# Altium schematic sheet Sheet1.SchDoc(Sheet1)
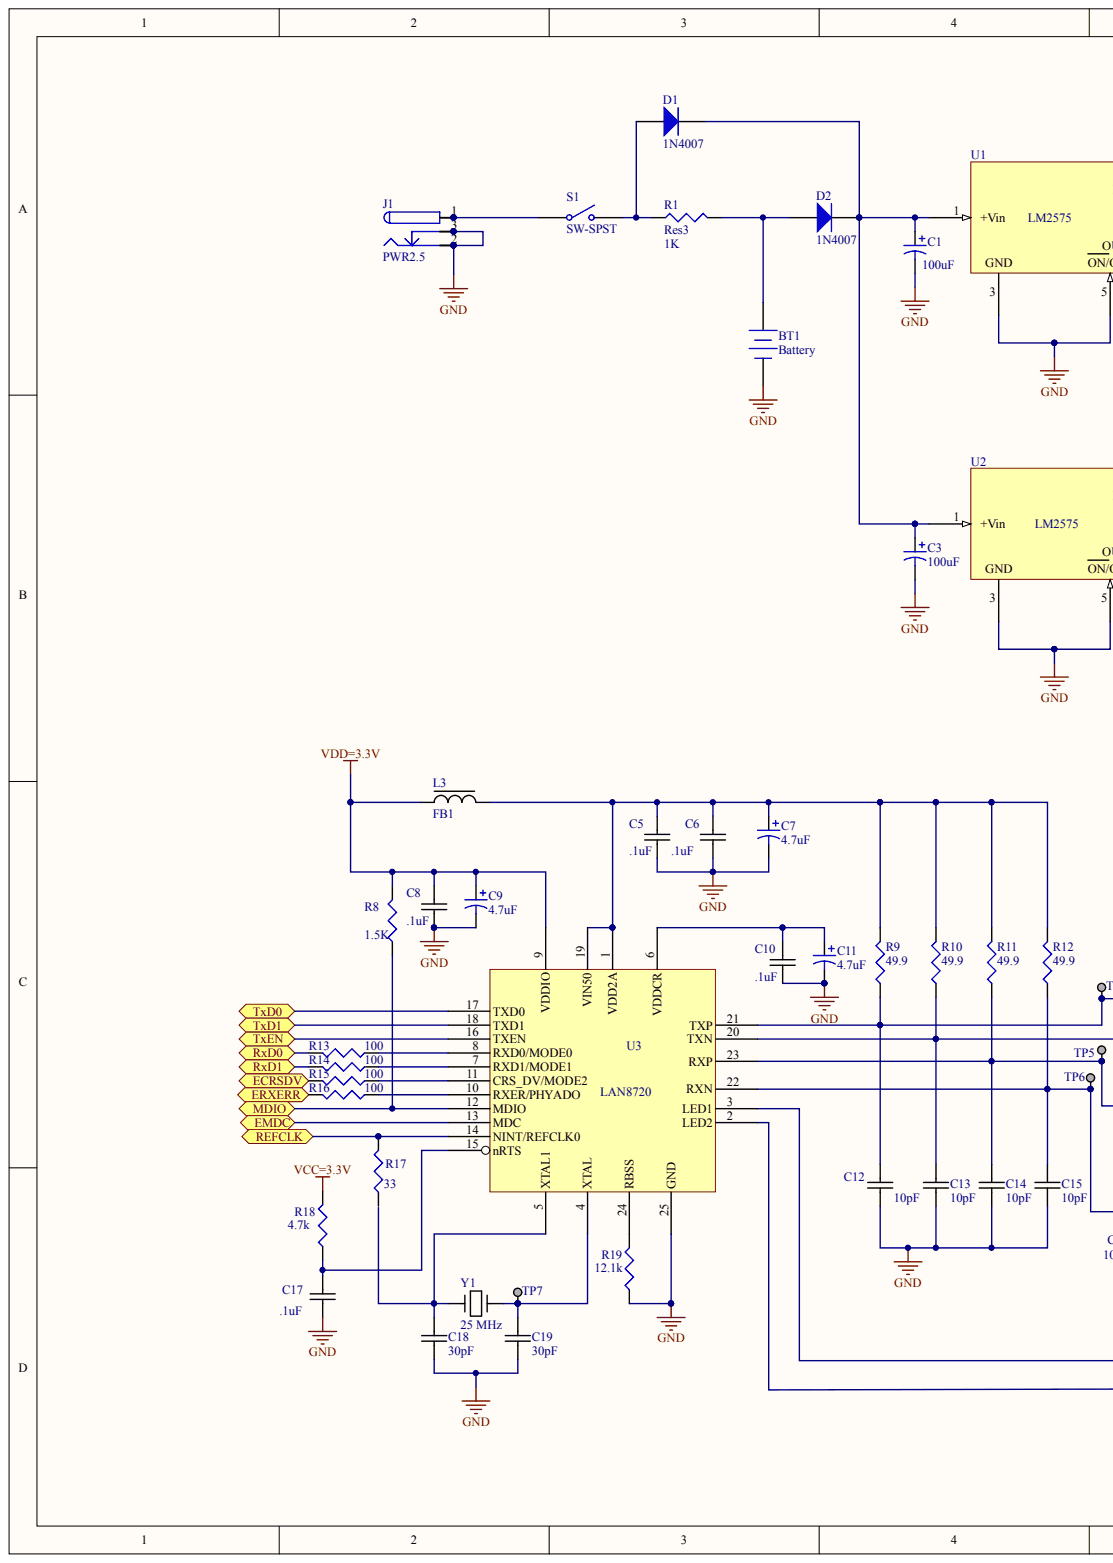
[diagram p0: Sheet1.SchDoc, left]
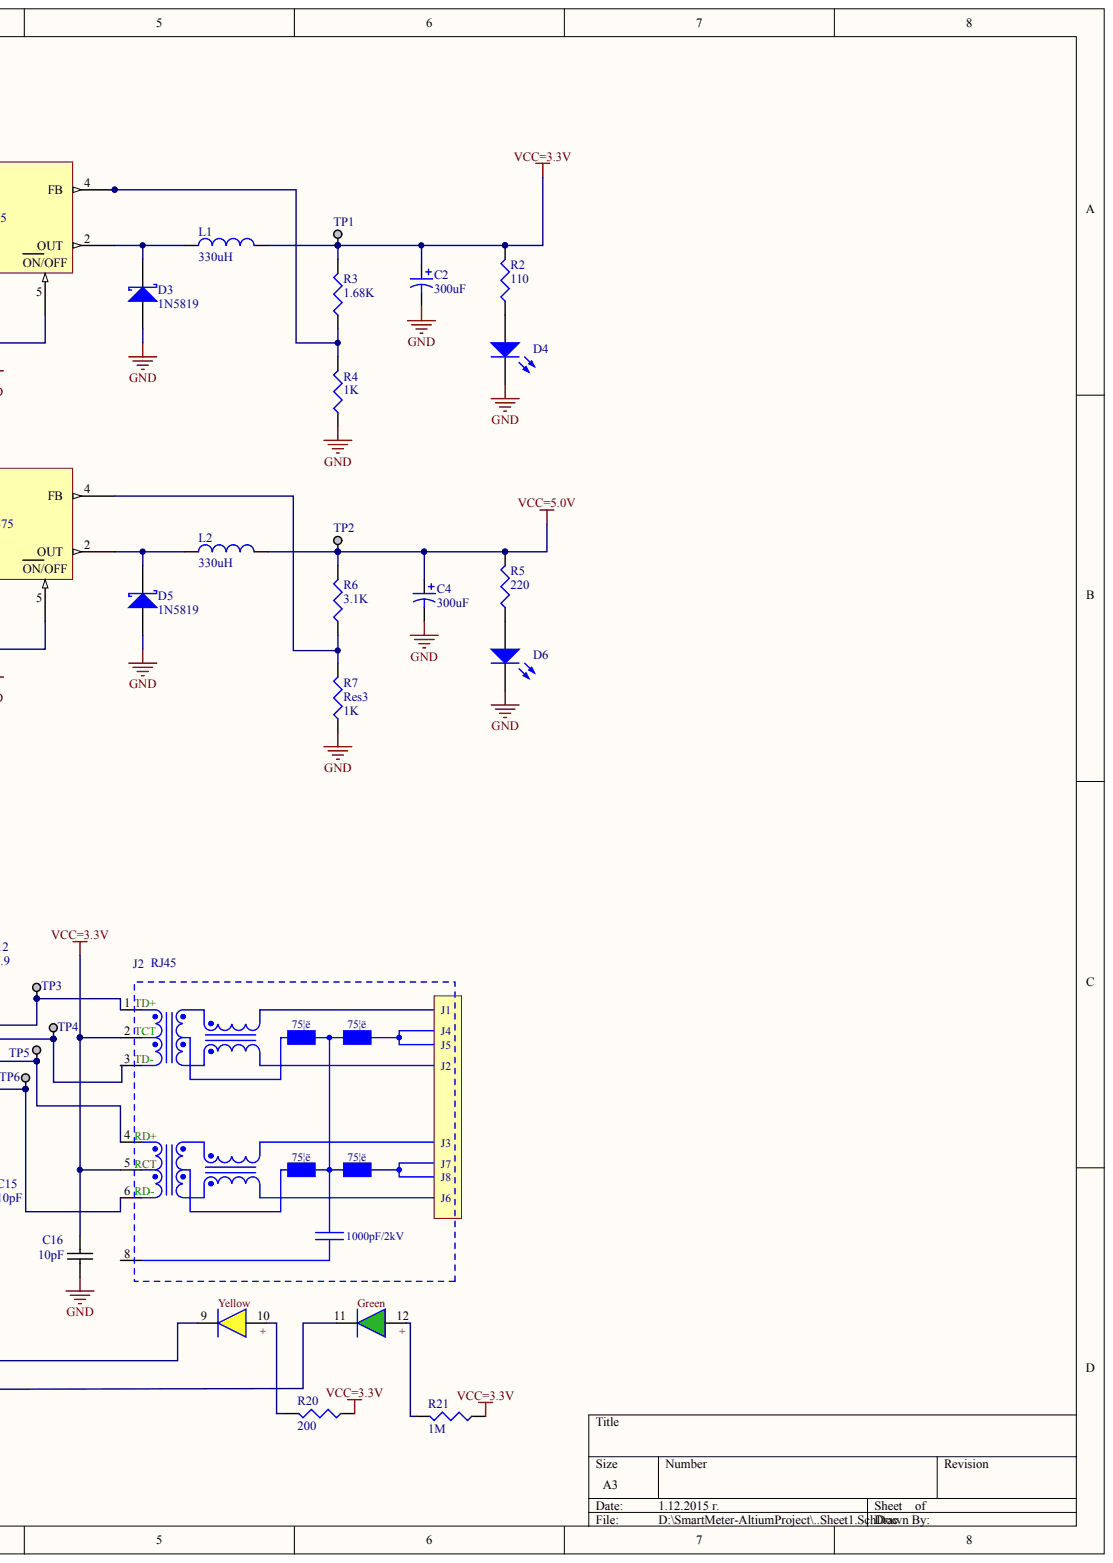
[diagram p1: Sheet1.SchDoc, right]

## Parts
BT1  Battery
C1  100uF
C2  300uF
C3  100uF
C4  300uF
C5  .1uF
C6  .1uF
C7  4.7uF
C8  .1uF
C9  4.7uF
C10  .1uF
C11  4.7uF
C12  10pF
C13  10pF
C14  10pF
C15  10pF
C16  10pF
C17  .1uF
C18  30pF
C19  30pF
D1  1N4007
D2  1N4007
D3  1N5819
D4  LED2  (value not drawn)
D5  1N5819
D6  LED2  (value not drawn)
J1  PWR2.5
J2  RJ45
L1  330uH
L2  330uH
L3  FB1
R1  Res3  value 1K
R2  110
R3  1.68K
R4  1K
R5  220
R6  3.1K
R7  Res3  value 1K
R8  1.5K
R9  49.9
R10  49.9
R11  49.9
R12  49.9
R13  100
R14  100
R15  100
R16  100
R17  33
R18  4.7k
R19  12.1k
R20  200
R21  1M
S1  SW-SPST
TP1  TESTPOINT  (value not drawn)
TP2  TESTPOINT  (value not drawn)
TP3  TESTPOINT  (value not drawn)
TP4  TESTPOINT  (value not drawn)
TP5  TESTPOINT  (value not drawn)
TP6  TESTPOINT  (value not drawn)
TP7  TESTPOINT  (value not drawn)
U1  LM2575
U2  LM2575
U3  LAN8720
Y1  25 MHz

## Ports
ECRSDV, EMDC, ERXERR, MDIO, REFCLK, RXD0, RXD1, TXD0, TXD1, TXEN

## Nets
GND: BT1.2 C1.2 C2.2 C3.2 C4.2 C5.2 C6.2 C7.2 C8.2 C9.2 C10.2 C11.2 C12.2 C13.2 C14.2 C15.2 C16.2 C17.2 C18.2 C19.2 D3.1 D4.2 D5.1 D6.2 J1.2 J1.2A J1.2B J1.3 J1.3A J1.3B R4.1 R7.1 R19.1 U1.3 U1.5 U2.3 U2.5 U3.25(GND)
VCC=3.3V: C2.1 C16.1 J2.2 J2.5 L1.2 R2.2 R3.2 R18.2 R20.1 R21.1 TP1.1
VCC=5.0V: C4.1 L2.2 R5.2 R6.2 TP2.1
VDD=3.3V: C8.1 C9.1 L3.1 R8.2 U3.9(VDDIO)
ECRSDV: R15.2 ; port ECRSDV
EMDC: U3.13(MDC) ; port EMDC
ERXERR: R16.2 ; port ERXERR
MDIO: R8.1 U3.12(MDIO) ; port MDIO
REFCLK: R17.2 U3.14(NINT/REFCLK0) ; port REFCLK
NetBT1_1: BT1.1 D2.1 R1.2
NetC1_1: C1.1 C3.1 D1.2 D2.2 U1.1(+Vin) U2.1(+Vin)
NetC5_1: C5.1 C6.1 C7.1 L3.2 R9.2 R10.2 R11.2 R12.2 U3.1(VDD2A) U3.19(VIN50)
NetC10_1: C10.1 C11.1 U3.6(VDDCR)
NetC12_1: C12.1 J2.1 R9.1 TP3.1 U3.21(TXP)
NetC13_1: C13.1 J2.3 R10.1 TP4.1 U3.20(TXN)
NetC14_1: C14.1 J2.4 R11.1 TP5.1 U3.23(RXP)
NetC15_1: C15.1 J2.6 R12.1 TP6.1 U3.22(RXN)
NetC17_1: C17.1 R18.1 U3.15(nRTS)
NetC18_1: C18.1 Y1.1
NetC19_1: C19.1 TP7.1 U3.4(XTAL) Y1.2
NetD1_1: D1.1 R1.1 S1.2
NetD3_2: D3.2 L1.1 U1.2(OUT)
NetD4_1: D4.1 R2.1
NetD5_2: D5.2 L2.1 U2.2(OUT)
NetD6_1: D6.1 R5.1
NetJ1_1: J1.1 J1.1A J1.1B S1.1
NetJ2_9: J2.9 U3.3(LED1)
NetJ2_10: J2.10 R20.2
NetJ2_11: J2.11 U3.2(LED2)
NetJ2_12: J2.12 R21.2
NetR3_1: R3.1 R4.2 U1.4(FB)
NetR6_1: R6.1 R7.2 U2.4(FB)
NetR13_1: R13.1 U3.8(RXD0/MODE0)
NetR13_2: R13.2 ; port RXD0
NetR14_1: R14.1 U3.7(RXD1/MODE1)
NetR14_2: R14.2 ; port RXD1
NetR15_1: R15.1 U3.11(CRS_DV/MODE2)
NetR16_1: R16.1 U3.10(RXER/PHYADO)
NetR19_2: R19.2 U3.24(RBSS)
NetU3_16: U3.16(TXEN) ; port TXEN
NetU3_17: U3.17(TXD0) ; port TXD0
NetU3_18: U3.18(TXD1) ; port TXD1

Not connected: J2 8; R17 1; U3 5(XTAL1)

## Library data of the parts
C1-C3 = (no symbol name): Note: Part Numbers shown are for ± 20% capacitance tolerance (X0).; PCB_Layout: Complies with Manufacturer's Recommendation.; DrillSize: Nominal, Rounded to the nearest 0.05mm; Published: 19-Mar-2004; DatasheetDocument: 23-May-2001; PackageReference: A'; ComponentLink1Description: Manufacturer Link; ComponentLink1URL: http://www.vishay.com/; ComponentLink2Description: Datasheet; ComponentLink2URL: http://www.vishay.com/docs/90008/94ss.pdf; Publisher: Altium Limited
  C1 C3: Value: 100uF
  C2: Value: 300uF
C4 = (no symbol name): Note: Part Numbers shown are for ± 20% capacitance tolerance (X0).; PCB_Layout: Complies with Manufacturer's Recommendation.; DrillSize: Nominal, Rounded to the nearest 0.05mm; Value: 6.8uF; Published: 19-Mar-2004; DatasheetDocument: 23-May-2001; PackageReference: A'; ComponentLink1Description: Manufacturer Link; ComponentLink1URL: http://www.vishay.com/; ComponentLink2Description: Datasheet; ComponentLink2URL: http://www.vishay.com/docs/90008/94ss.pdf; Publisher: Altium Limited
C5 C6 C8 C10 = (no symbol name): Value: .1uF 16V; Supplier 1: Digi-Key; Supplier Part Number 1: 709-1171-2-ND
C7 C9 C11 = (no symbol name): Value: 4.7uF 6.3 V 10%; Published: 8-Jun-2000; LatestRevisionDate: 29-May-2009; LatestRevisionNote: IPC-7351 Footprint Added.; PackageReference: C0805; PackageDescription: Chip Capacitor; Publisher: Altium Limited
C17 = (no symbol name): Value: 30pF; Supplier 1: Digi-Key; Supplier Part Number 1: 709-1171-2-ND
J2 = (no symbol name): Manufacturer: Wurth Elektronik; PartNo: 74990112116A; ComponentLink1 Description: Manufacturer; ComponentLink2 Description: Datasheet; ComponentLink1 URL: http://www.we-online.com; ComponentLink2 URL: http://katalog.we-online.de/pbs/datasheet/74990112116A.pdf; Created Date: 13-Aug-15; Created By: Baojun Chen
L1 = (no symbol name): Code_IEC: 1005; Published: 8-Jun-2000; LatestRevisionDate: 29-May-2009; LatestRevisionNote: IPC-7351 Footprint Added.; PackageReference: 0402-A; PackageDescription: Chip Inductor; Code_JEITA: 0402; Value: 330uH; mfr PN: *; Publisher: Altium Limited
L2 = (no symbol name): Code_IEC: 1005; Value: 300uH; Published: 8-Jun-2000; LatestRevisionDate: 29-May-2009; LatestRevisionNote: IPC-7351 Footprint Added.; PackageReference: 0402-A; PackageDescription: Chip Inductor; Code_JEITA: 0402; Publisher: Altium Limited
L3 = (no symbol name): mfr PN: BLM18PG121SNID
R3 = (no symbol name): Value: 1.68K .125W 1%; Published: 8-Jun-2000; LatestRevisionDate: 29-May-2009; LatestRevisionNote: IPC-7351 Footprint Added.; PackageReference: J1-0603; PackageDescription: Chip Resistor; Package reference: 0603; Publisher: Altium Limited
R4 = (no symbol name): Value: 1K/.125W/1%; Published: 8-Jun-2000; LatestRevisionDate: 29-May-2009; LatestRevisionNote: IPC-7351 Footprint Added.; PackageReference: J1-0603; PackageDescription: Chip Resistor; mfr PN: *; Publisher: Altium Limited
R6 = (no symbol name): Value: 1K; Published: 8-Jun-2000; LatestRevisionDate: 29-May-2009; LatestRevisionNote: IPC-7351 Footprint Added.; PackageReference: J1-0603; PackageDescription: Chip Resistor; : mfr PN; Publisher: Altium Limited
R8 = (no symbol name): Value: 1.5k/ .125W /1%; Published: 8-Jun-2000; LatestRevisionDate: 29-May-2009; LatestRevisionNote: IPC-7351 Footprint Added.; PackageReference: J1-0603; PackageDescription: Chip Resistor; : mfr PN; Publisher: Altium Limited
R9-R12 R14-R16 = (no symbol name): Value: 49.9 .125W 1%; Published: 8-Jun-2000; LatestRevisionDate: 29-May-2009; LatestRevisionNote: IPC-7351 Footprint Added.; PackageReference: J1-0603; PackageDescription: Chip Resistor; : mfr PN; Publisher: Altium Limited
R13 = (no symbol name): Value: 100 .125W 1%; Published: 8-Jun-2000; LatestRevisionDate: 29-May-2009; LatestRevisionNote: IPC-7351 Footprint Added.; PackageReference: J1-0603; PackageDescription: Chip Resistor; : mfr PN; Publisher: Altium Limited
R17 = (no symbol name): Value: 33/ .125W 1%; Published: 8-Jun-2000; LatestRevisionDate: 29-May-2009; LatestRevisionNote: IPC-7351 Footprint Added.; PackageReference: J1-0603; PackageDescription: Chip Resistor; : mfr PN; Publisher: Altium Limited
R18 = (no symbol name): Value: 4.7/ .125W 1%; Published: 8-Jun-2000; LatestRevisionDate: 29-May-2009; LatestRevisionNote: IPC-7351 Footprint Added.; PackageReference: J1-0603; PackageDescription: Chip Resistor; : mfr PN; Publisher: Altium Limited
R19 = (no symbol name): Value: 12.1k/ .125W 1%; Published: 8-Jun-2000; LatestRevisionDate: 29-May-2009; LatestRevisionNote: IPC-7351 Footprint Added.; PackageReference: J1-0603; PackageDescription: Chip Resistor; : mfr PN; Publisher: Altium Limited
R20 = (no symbol name): Value: 200/.125W/ 1%; Published: 8-Jun-2000; LatestRevisionDate: 29-May-2009; LatestRevisionNote: IPC-7351 Footprint Added.; PackageReference: J1-0603; PackageDescription: Chip Resistor; manufacture P/N: *; Publisher: Altium Limited
R21 = (no symbol name): Value: 1K 1%; Published: 8-Jun-2000; LatestRevisionDate: 29-May-2009; LatestRevisionNote: IPC-7351 Footprint Added.; PackageReference: J1-0603; PackageDescription: Chip Resistor; manufacture P/N: *; Publisher: Altium Limited
TP1-TP7 = (no symbol name): Manufacturer Part Number: 5010; Manufacturer: Keystone Electronics; Reel: TH; Supplier 1: Digi-Key; Supplier Part Number 1: 5010K-ND
BT1 C12-C16 C18 C19 D1-D6 J1 R1 R2 R5 R7 S1 U1-U3 Y1 = (no symbol name)
  BT1: Published: 24-Mar-1999; LatestRevisionDate: 17-Jul-2002; LatestRevisionNote: Re-released for DXP Platform.; PackageReference: BAT-2; PackageDescription: Battery; 2 Leads
  C12-C16: Supplier 1: Digi-Key; Supplier Part Number 1: 709-1171-2-ND; Value: 10pF
  C18 C19: Supplier 1: Digi-Key; Supplier Part Number 1: 709-1171-2-ND; Value: 30pF
  D1 D2: Published: 8-Jun-2000; LatestRevisionDate: 17-Jul-2002; LatestRevisionNote: Re-released for DXP Platform.; DatasheetVersion: 1998; PackageVersion: Aug-1999; PackageReference: DO-41; PackageDescription: Diode, Axial; Body 5.2 x 2.7 mm (LxDia. max), Lead Dia. 0.86 mm (max); Code_JEDEC: DO-204-AL; ComponentLink1Description: Manufacturer Link; ComponentLink1URL: http://www.fairchildsemi.com/; ComponentLink2Description: Datasheet; ComponentLink2URL: http://www.fairchildsemi.com/ds/1N%2F1N4001.pdf
  D3: Published: 8-Jun-2000; LatestRevisionDate: 17-Jul-2002; LatestRevisionNote: Re-released for DXP Platform.; PackageReference: SMB; PackageDescription: DO-214-AA/SMB; 2 C-Bend Leads; Body 5.3 x 3.6 mm, inc. leads (LxW); Code_JEDEC: DO-214-AA; mfr PN: *
  D4 D6: Published: 8-Jun-2000; LatestRevisionDate: 17-Jul-2002; LatestRevisionNote: Re-released for DXP Platform.; PackageVersion: Feb-2002; PackageReference: 3.2X1.6X1.1; PackageDescription: SM LED; 2 Flat Leads
  D5: Published: 8-Jun-2000; LatestRevisionDate: 17-Jul-2002; LatestRevisionNote: Re-released for DXP Platform.; PackageReference: SMB; PackageDescription: DO-214-AA/SMB; 2 C-Bend Leads; Body 5.3 x 3.6 mm, inc. leads (LxW); Code_JEDEC: DO-214-AA; mr PN: *; Value: *
  J1: Published: 17-Dec-2003; DatasheetDocument: 09-May-2002; ComponentLink1Description: Manufacturer Link; ComponentLink1URL: http://domino.kycon.com/internet/Products.html
  R1 R7: Published: 8-Jun-2000; LatestRevisionDate: 29-May-2009; LatestRevisionNote: IPC-7351 Footprint Added.; PackageReference: J1-0603; PackageDescription: Chip Resistor
  R2: Published: 8-Jun-2000; LatestRevisionDate: 29-May-2009; LatestRevisionNote: IPC-7351 Footprint Added.; PackageReference: J1-0603; PackageDescription: Chip Resistor; Value: 110; : mfr PN
  R5: Published: 8-Jun-2000; LatestRevisionDate: 29-May-2009; LatestRevisionNote: IPC-7351 Footprint Added.; PackageReference: J1-0603; PackageDescription: Chip Resistor; Value: 220; : mfr PN
  S1: Published: 24-Mar-1999; LatestRevisionDate: 17-Jul-2002; LatestRevisionNote: Re-released for DXP Platform.; PackageReference: SPST-2; PackageDescription: Switch; 2 Leads
  Y1: Value: 25 Mhz
  BT1 D1-D6 J1 R1 R2 R5 R7 S1: Publisher: Altium Limited
